# Altium schematic sheet clock.SchDoc
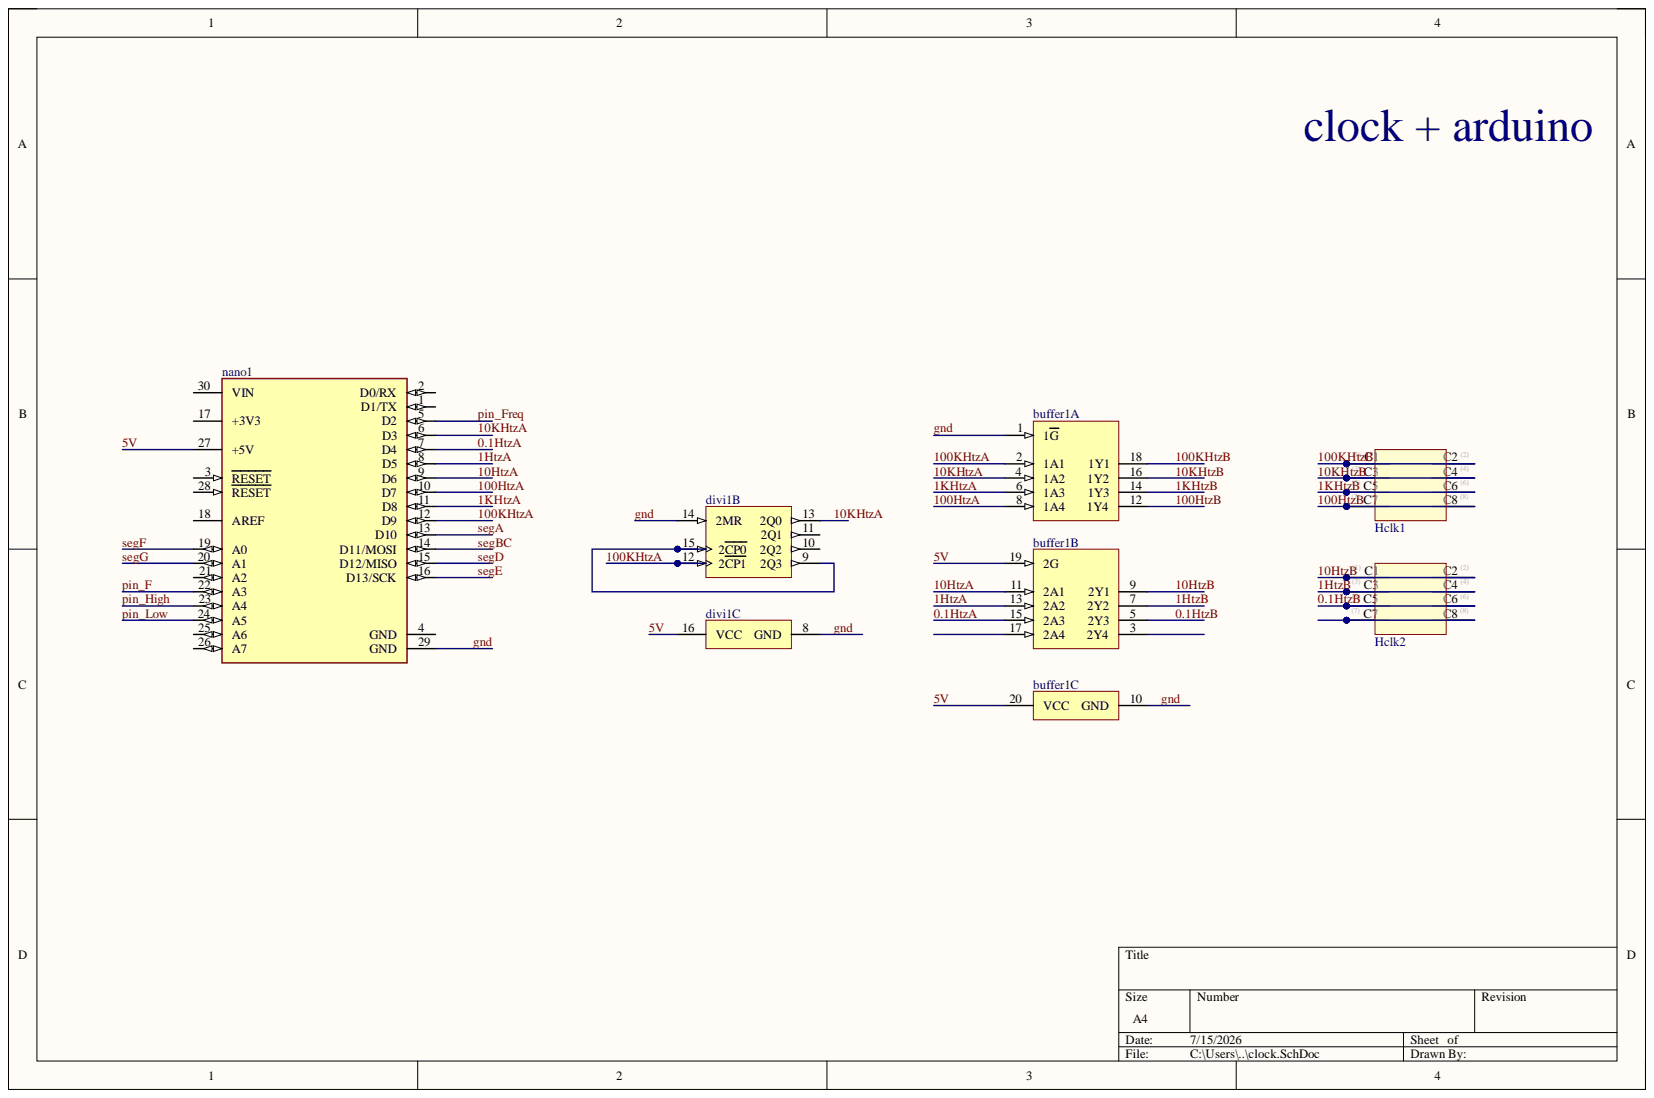
[diagram p0: clock.SchDoc]

## Parts
buffer1  SN74LS241N  [N0020A]  (value not drawn)  (parts A,B,C)
divi1  CD74HC390E  [N0016A]  (value not drawn)  (parts B,C)
Hclk1  header 2x4  [header 2x4]  (value not drawn)
Hclk2  header 2x4  [header 2x4]  (value not drawn)
nano1  A000005  [FP-A000005-MFG]  (value not drawn)

## Nets
0.1HtzA: buffer1.15(2A3) nano1.7(D4)
0.1HtzB: buffer1.5(2Y3) Hclk2.5 Hclk2.6
1HtzA: buffer1.13(2A2) nano1.8(D5)
1HtzB: buffer1.7(2Y2) Hclk2.3 Hclk2.4
1KHtzA: buffer1.6(1A3) nano1.11(D8)
1KHtzB: buffer1.14(1Y3) Hclk1.5 Hclk1.6
5V: buffer1.19(2G) buffer1.20(VCC) divi1.16(VCC) nano1.27(+5V)
10HtzA: buffer1.11(2A1) nano1.9(D6)
10HtzB: buffer1.9(2Y1) Hclk2.1 Hclk2.2
10KHtzA: buffer1.4(1A2) divi1.13(2Q0) nano1.6(D3)
10KHtzB: buffer1.16(1Y2) Hclk1.3 Hclk1.4
100HtzA: buffer1.8(1A4) nano1.10(D7)
100HtzB: buffer1.12(1Y4) Hclk1.7 Hclk1.8
100KHtzA: buffer1.2(1A1) divi1.12(2CP1) nano1.12(D9)
100KHtzB: buffer1.18(1Y1) Hclk1.1 Hclk1.2
gnd: buffer1.1(1G) buffer1.10(GND) divi1.8(GND) divi1.14(2MR) nano1.29(GND)
pin_F: nano1.22(A3)
pin_Freq: nano1.5(D2)
pin_High: nano1.23(A4)
pin_Low: nano1.24(A5)
segA: nano1.13(D10)
segBC: nano1.14(D11/MOSI)
segD: nano1.15(D12/MISO)
segE: nano1.16(D13/SCK)
segF: nano1.19(A0)
segG: nano1.20(A1)
Netdivi1_9: divi1.9(2Q3) divi1.15(2CP0)
NetHclk2_7: Hclk2.7 Hclk2.8

Not connected: buffer1 3(2Y4) 17(2A4); divi1 10(2Q2) 11(2Q1); nano1 1(D1/TX) 2(D0/RX) 3(RESET) 4(GND) 17(+3V3) 18(AREF) 21(A2) 25(A6) 26(A7) 28(RESET) 30(VIN)

## Library data of the parts
buffer1 = CMP-0440-00144-3: Octal Buffers And Line Drivers With 3-State Outputs, N0020A, TUBE; Manufacturer: Texas Instruments; Bits(#): 8; F @ Nom Voltage(Max)(Mhz): 35; ICC @ Nom Voltage(Max)(mA): 0.046; Input Type: TTL; Rating: Catalog; tpd @ Nom Voltage(Max)(ns): 18; VCC(Max)(V): 5.25; VCC(Min)(V): 4.75; Voltage(Nom)(V): 5; Code_JEDEC: MS-001; Component Kind: Standard; DatasheetVersion: SDLS144C; Generic Part Number: SN74LS241; Library Name: Altium Content Vault; Operating Temperature Range(C): 0 to 70; Output Type: TTL; PackageReference: N0020A; PackageVersion: http://www.ti.com/lit/ml/mpdi002c/mpdi002c.pdf
divi1 = CMP-1623-00557-2: High Speed CMOS Logic Dual Decade Ripple Counters, N0016A, TUBE; Manufacturer: Texas Instruments; Bits(#): 4; F @ Nom Voltage(Max)(Mhz): 28; ICC @ Nom Voltage(Max)(mA): 0.08; Input Type: LVTTL/CMOS; Inputs: 1; Output Drive (IOL/IOH)(Max)(mA): 5.2/-5.2; Rating: Catalog; Schmitt Trigger: No; Technology Family: HC; tpd @ Nom Voltage(Max)(ns): 52; VCC(Max)(V): 6; VCC(Min)(V): 2; Voltage(Nom)(V): 3.3,5; Code_JEDEC: MS-001; Component Kind: Standard; DatasheetVersion: SCHS185C; Function: Counter; Generic Part Number: CD74HC390; Library Name: Altium Content Vault; Operating Temperature Range(C): -55 to 125; Output Type: CMOS; PackageReference: N0016A; Type: Decade
Hclk1 Hclk2 = header 2x4: header male 2x4; Component Kind: Standard; Library Name: Schlib1.SchLib; Parameter 1: header 2x4
nano1 = CMP-189986-000002-1: ARDUINO NANO ATMEGA328 EVAL BRD; Core Architecture: AVR; Data Bus Width: 8b; Evaluation Kit: Yes; Interface: I2C; Number of Bits: 8; Operating Supply Voltage: 12V; Component Kind: Standard; Height: 0.25inch; Length: 7inch; Library Name: Altium Content Vault; Package Quantity: 50; Packaging: Bulk; REACH SVHC: No SVHC; Series: AVR® ATmega; Weight: 0.358383oz; Width: 5inch
